# Altium schematic sheet VLC.SchDoc
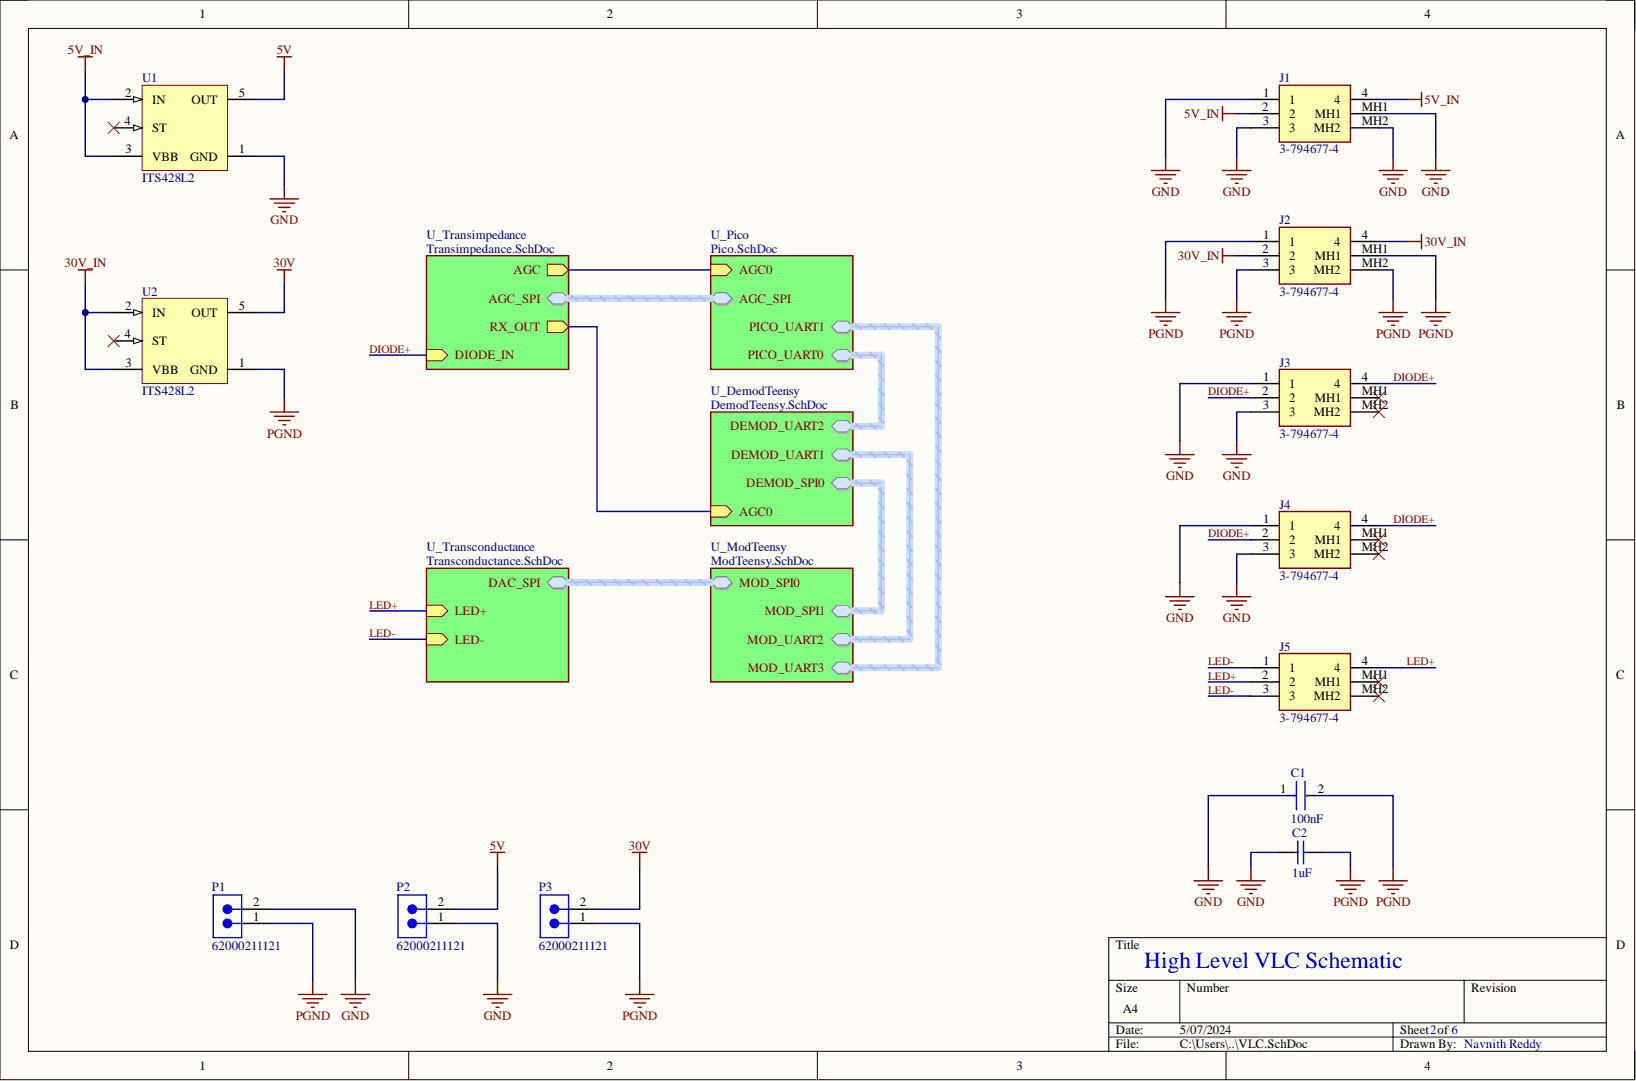
[diagram p0: VLC.SchDoc]

## Parts
C1  100nF  [FP-C0805C-DN-MFG]
C2  1uF  [CAPC2013X145X50LL20T25]
J1  3-794677-4  [37946774]
J2  3-794677-4  [37946774]
J3  3-794677-4  [37946774]
J4  3-794677-4  [37946774]
J5  3-794677-4  [37946774]
P1  62000211121  [62000211121]
P2  62000211121  [62000211121]
P3  62000211121  [62000211121]
U1  ITS428L2  [INF-PG-TO252-5-11_M]
U2  ITS428L2  [INF-PG-TO252-5-11_M]

## Nets
5V: P2.2 U1.5(OUT)
5V_IN: J1.2 J1.4 U1.2(IN) U1.3(VBB)
30V: P3.2 U2.5(OUT)
30V_IN: J2.2 J2.4 U2.2(IN) U2.3(VBB)
GND: C1.1 C2.2 J1.1 J1.3 J1.MH1 J1.MH2 J3.1 J3.3 J4.1 J4.3 P1.2 P2.1 U1.1(GND)
PGND: C1.2 C2.1 J2.1 J2.3 J2.MH1 J2.MH2 P1.1 P3.1 U2.1(GND)
DIODE+: J3.2 J3.4 J4.2 J4.4
LED+: J5.2 J5.4
LED-: J5.1 J5.3
NetJ3_MH1: J3.MH1
NetJ3_MH2: J3.MH2
NetJ4_MH1: J4.MH1
NetJ4_MH2: J4.MH2
NetJ5_MH1: J5.MH1
NetJ5_MH2: J5.MH2
NetU1_4: U1.4(ST)
NetU2_4: U2.4(ST)
AGC:
AGC0:
AGC_SPI.SPI_CS:
AGC_SPI.SPI_SCK:
AGC_SPI.SPI_SDI:
DAC_SPI.SPI_CS:
DAC_SPI.SPI_SCK:
DAC_SPI.SPI_SDI:
DEMOD_SPI0.SPI_CS:
DEMOD_SPI0.SPI_SCK:
DEMOD_SPI0.SPI_SDI:
DEMOD_UART1.MRX:
DEMOD_UART1.MTX:
DEMOD_UART2.DRX:
DEMOD_UART2.DTX:
MOD_UART3.MRX:
MOD_UART3.MTX:

## Library data of the parts
C1 = CMP-2007-00274-2: CAP CER 0.1UF 16V X7R 0805; Manufacturer: KEMET; Manufacturer Part Number: C0805C104K4RACTU; Capacitance: 0.10 μF; Material: Ceramic; Temperature Coefficient: 30ppm/°C; Tolerance: 0.1; Value: 0.10 μF; Automotive: No; Category: Cap; Component Kind: Standard; ComponentLink2Description: Datasheet; ComponentLink2URL: https://content.kemet.com/datasheets/KEM_C1003_C0G_SMD.pdf; Device Class L1: Passive Components; Device Class L2: Capacitors; Device Class L3: Ceramic Capacitors; Digikey Description: CAP CER 0.1UF 16V X7R 0805; Height: 0.88mm; Ipc Land Pattern Name: CAPC200125X78; Lead Free: Yes; Library Name: Altium Content Vault; Mouser Description: Multilayer Ceramic Capacitors MLCC - SMD/SMT 16volts 0.1uF X7R 10%; Octopart: https://octopart.com/c0805c104k4ractu-kemet-92155; Package: C0805C-DN; RoHS: TRUE; Temperature: -55°C to +125°C; Temperature Characteristic: X7R; Temperature Range High: +125°C; Temperature Range Low: -55°C; Voltage Rating: 16 V
C2 = CMP-1036-04746-2: Manufacturer: Kemet; Part Number: C0805C105K4PACTU; Component Kind: Standard; Library Name: Altium Content Vault
J1-J5 = 3-794677-4: Connector; Manufacturer_Name: TE Connectivity; Arrow Part Number: 3-794677-4; Arrow Price/Stock: https://www.arrow.com/en/products/3-794677-4/te-connectivity?region=europe; Component Kind: Standard; Datasheet Link: https://www.te.com/commerce/DocumentDelivery/DDEController?Action=srchrtrv&DocNm=micro-mate-n-lok-connector-qrg&DocType=Data%20Sheet&DocLang=English&PartCntxt=3-794677-4&DocFormat=pdf; Height: 8.67mm; Manufacturer_Part_Number: 3-794677-4; Mouser Part Number: 571-3-794677-4; Mouser Price/Stock: https://www.mouser.co.uk/ProductDetail/TE-Connectivity-AMP/3-794677-4?qs=6xDM53S3Z3IXPJK6%252BrOgPw%3D%3D; Library Name: VLC_TX.SchLib
P1-P3 = CMP-1502-00956-3: NexarPartId: 61924778; Contact Resistance: 20mΩ; Current Rating: 2A; Gender: Male; Number of Contacts: 2; Operating Supply Voltage: 200V; Component Kind: Standard; Contact Material: Gold; Height: 6.35mm; Lead Pitch: 2mm; Library Name: Altium Content Vault; Max Operating Temperature: 105°C; Min Operating Temperature: -40°C; Number of Pins: 2; Number of Rows: 1; Orientation: Vertical; RoHS: Non-Compliant; Voltage Rating: 200V
U1 U2 = CMP-1095-00118-1: Smart High-Side Power Switch for Industrial Applications 1 Channel: 60 mOhm Status Feedback, 4.75 to 41 V Operating, -30 to 85 degC, PG-TO252-5-11, Reel, Green; Manufacturer: Infineon; Channels: 1; Diagnostic: Digital; Green: TRUE; IL (nom)min - all channels active: 5.8Â A; IL (SC): 22 A; Operating Voltage Range (max): 41 V; Operating Voltage Range (min): 4.75Â V; Ptot (max): 75 W; RON (max) @ Tj max: 120 mOhm; RON @ 25 degC: 60 mOhm (max); toff (max): 200 us; Component Kind: Standard; ComponentLink1Description: Manufacturer URL; ComponentLink1URL: http://www.infineon.com/; ComponentLink2Description: Datasheet; ComponentLink2URL: http://www.infineon.com/dgdl/ITS428L2_DS11_20081010.pdf?folderId=db3a304314dca389011537739e37155f&fileId=db3a30431c69a49d011cdcc9a1fd41df; ComponentLink3Description: Package Specification; ComponentLink3URL: http://www.infineon.com/dgdl/TLE7276-Data+Sheet-+v1_11.pdf?folderId=db3a304412b407950112b408e8c90004&fileId=db3a304412b407950112b436c53f66c8&sId=db3a30433db6f09f013dc0e43c0428c1; DatasheetVersion: Rev. 1.1; Halogen-free: TRUE; IL (nom)min - one channel active: 5.8Â A; IL (SCp): 22 A; Library Name: Altium Content Vault; Load Current (typ): 5.8Â A; Mounting Technology: Surface Mount; PackageDescription: 5-Pin Plastic (Green) Transistor Single Outline Package, 6.5 x 6.12 mm Body, 1.14 mm Pitch; PackageReference: PG-TO252-5-11; PackageVersion: Nov-10; Packing: Reel; PartNumber: ITS428L2; ton (max): 200 us
